# Altium schematic sheet 04 MCU.SchDoc("U_04 MCU")
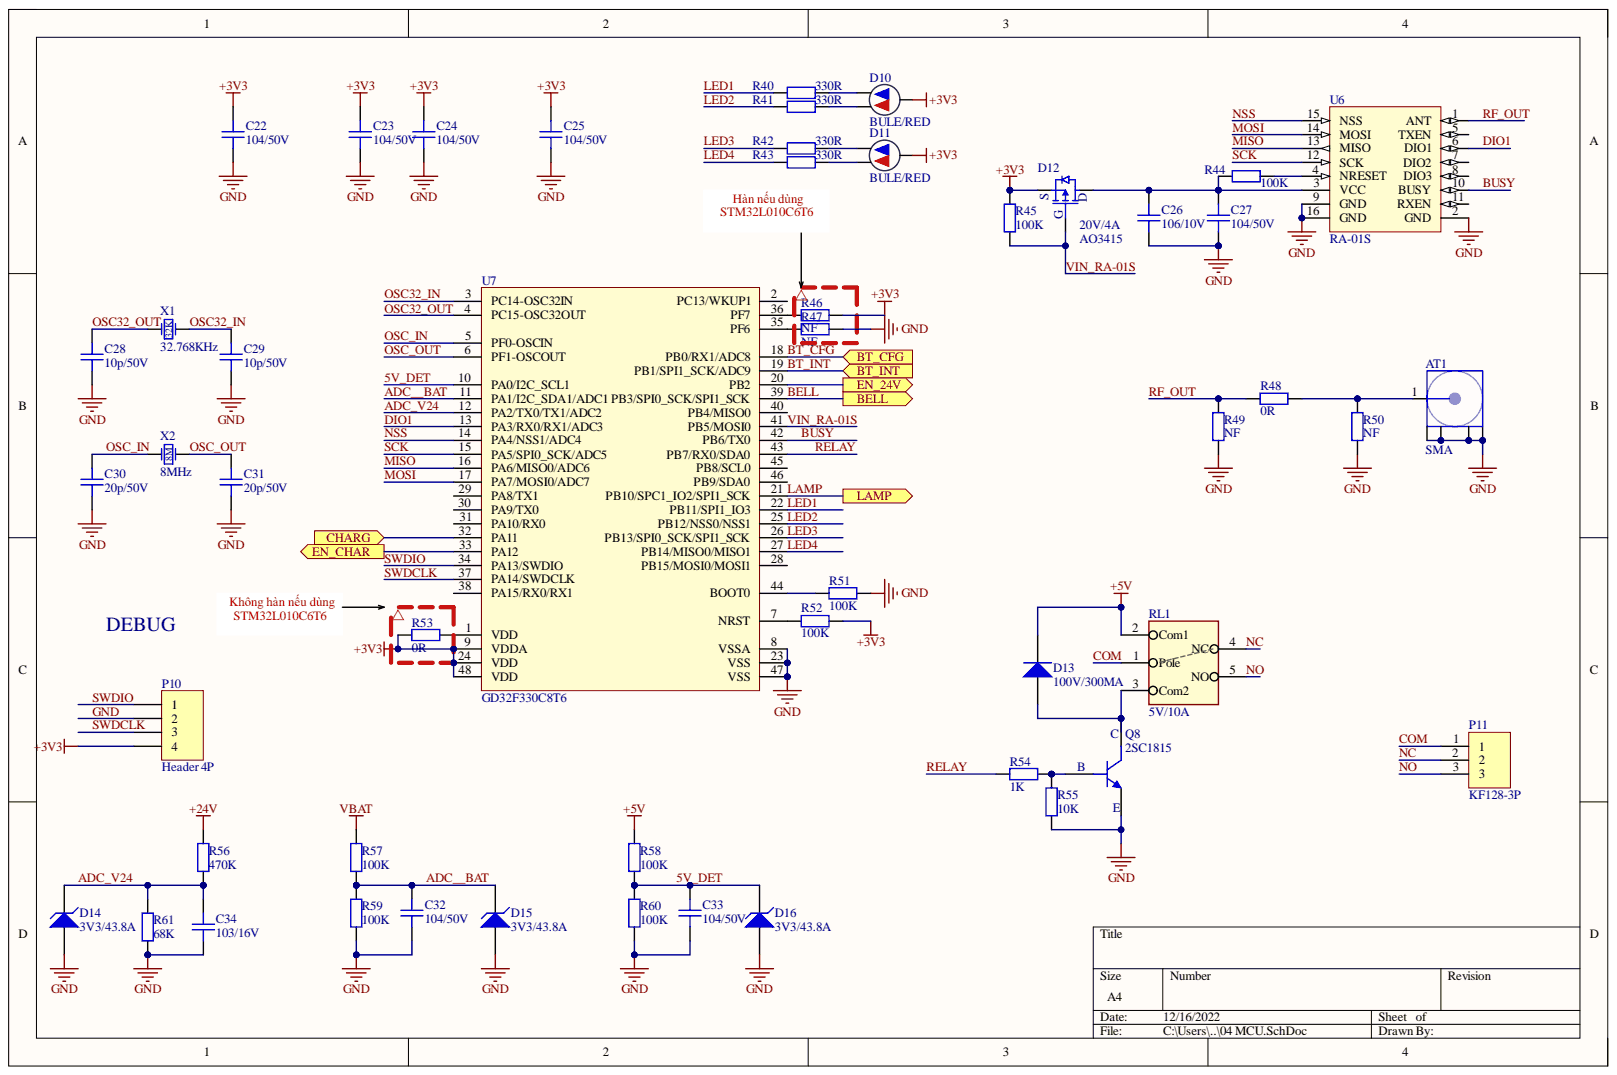
[diagram p0: 04 MCU.SchDoc]

## Parts
AT1  SMA  [SMA-KWE-17-V1]
C22  104/50V  [CAPC-0603 (1608) - HD]
C23  104/50V  [CAPC-0603 (1608) - HD]
C24  104/50V  [CAPC-0603 (1608) - HD]
C25  104/50V  [CAPC-0603 (1608) - HD]
C26  106/10V  [CAPC-0603 (1608) - HD]
C27  104/50V  [CAPC-0603 (1608) - HD]
C28  10p/50V  [CAPC-0603 (1608) - HD]
C29  10p/50V  [CAPC-0603 (1608) - HD]
C30  20p/50V  [CAPC-0603 (1608) - HD]
C31  20p/50V  [CAPC-0603 (1608) - HD]
C32  104/50V  [CAPC-0603 (1608) - HD]
C33  104/50V  [CAPC-0603 (1608) - HD]
C34  103/16V  [CAPC-0603 (1608) - HD]
D10  BULE/RED  [LED_3MM_DUALCOLOR]
D11  BULE/RED  [LED_3MM_DUALCOLOR]
D12  20V/4A  [SOT23_3]
D13  100V/300MA  [SOD-123]
D14  3V3/43.8A  [SOD123]
D15  3V3/43.8A  [SOD123]
D16  3V3/43.8A  [SOD123]
P10  Header 4P  [HDR1X4]
P11  KF128-3P  [KF128 - 3P 5.08MM]
Q8  2SC1815  [SOT23_3]
R40  330R  [RESC-0603 (1608) - HD]
R41  330R  [RESC-0603 (1608) - HD]
R42  330R  [RESC-0603 (1608) - HD]
R43  330R  [RESC-0603 (1608) - HD]
R44  100K  [RESC-0603 (1608) - HD]
R45  100K  [RESC-0603 (1608) - HD]
R46  NF  [RESC-0603 (1608) - HD]
R47  NF  [RESC-0603 (1608) - HD]
R48  0R  [RESC-0603 (1608) - HD]
R49  NF  [RESC-0603 (1608) - HD]
R50  NF  [RESC-0603 (1608) - HD]
R51  100K  [RESC-0603 (1608) - HD]
R52  100K  [RESC-0603 (1608) - HD]
R53  0R  [RESC-0603 (1608) - HD]
R54  1K  [RESC-0603 (1608) - HD]
R55  10K  [RESC-0603 (1608) - HD]
R56  470K  [RESC-0603 (1608) - HD]
R57  100K  [RESC-0603 (1608) - HD]
R58  100K  [RESC-0603 (1608) - HD]
R59  100K  [RESC-0603 (1608) - HD]
R60  100K  [RESC-0603 (1608) - HD]
R61  68K  [RESC-0603 (1608) - HD]
RL1  5V/10A  [RELAY 5V]
U6  RA-01S  [Lora1276 V1.2]
U7  GD32F330C8T6  [LQFP-48]
X1  32.768KHz  [CRYSTAL_ABS06 2x1.2mm]
X2  8MHz  [CRYSTAL_ABM3 5x3.2mm]

## Ports
BELL, BT_CFG, BT_INT, CHARG, EN_24V, EN_CHAR, LAMP

## Nets
+3V3: C22.2 C23.2 C24.2 C25.2 D10.2 D11.2 D12.2 P10.4 R45.2 R46.1 R52.2 R53.2 U7.9(VDDA) U7.24(VDD) U7.48(VDD)
+24V: R56.2
VBAT: R57.2
5V_DET: C33.2 D13.2 D16.2 R58.1 R58.2 R60.2 RL1.2(Com1) U7.10(PA0/I2C_SCL1)
ADC__BAT: C32.2 D15.2 R57.1 R59.2 U7.11(PA1/I2C_SDA1/ADC1)
ADC_V24: C34.2 D14.2 R56.1 R61.2 U7.12(PA2/TX0/TX1/ADC2)
BELL: U7.39(PB3/SPI0_SCK/SPI1_SCK) ; port BELL
BT_CFG: U7.18(PB0/RX1/ADC8) ; port BT_CFG
BT_INT: U7.19(PB1/SPI1_SCK/ADC9) ; port BT_INT
BUSY: U6.10(BUSY) U7.42(PB6/TX0)
CHARG: U7.32(PA11) ; port CHARG
COM: P11.1 RL1.1(Pole)
DIO1: U6.6(DIO1) U7.13(PA3/RX0/RX1/ADC3)
EN_24V: U7.20(PB2) ; port EN_24V
EN_CHAR: U7.33(PA12) ; port EN_CHAR
GND: AT1.2 C22.1 C23.1 C24.1 C25.1 C26.1 C27.1 C28.1 C29.1 C30.1 C31.1 C32.1 C33.1 C34.1 D14.1 D15.1 D16.1 P10.2 Q8.2 R47.1 R49.1 R50.1 R51.2 R55.1 R59.1 R60.1 R61.1 U6.2(GND) U6.9(GND) U6.16(GND) U7.8(VSSA) U7.23(VSS) U7.47(VSS)
LAMP: U7.21(PB10/SPC1_IO2/SPI1_SCK) ; port LAMP
LED1: R40.1 U7.22(PB11/SPI1_IO3)
LED2: R41.1 U7.25(PB12/NSS0/NSS1)
LED3: R42.1 U7.26(PB13/SPI0_SCK/SPI1_SCK)
LED4: R43.1 U7.27(PB14/MISO0/MISO1)
MISO: U6.13(MISO) U7.16(PA6/MISO0/ADC6)
MOSI: U6.14(MOSI) U7.17(PA7/MOSI0/ADC7)
NC: P11.2 RL1.4(NC)
NO: P11.3 RL1.5(NO)
NSS: U6.15(NSS) U7.14(PA4/NSS1/ADC4)
OSC32_IN: C29.2 U7.3(PC14-OSC32IN) X1.2
OSC32_OUT: C28.2 U7.4(PC15-OSC32OUT) X1.1
OSC_IN: C30.2 U7.5(PF0-OSCIN) X2.1
OSC_OUT: C31.2 U7.6(PF1-OSCOUT) X2.2
RELAY: R54.1 U7.43(PB7/RX0/SDA0)
RF_OUT: R48.1 R49.2 U6.1(ANT)
SCK: U6.12(SCK) U7.15(PA5/SPI0_SCK/ADC5)
SWDCLK: P10.3 U7.37(PA14/SWDCLK)
SWDIO: P10.1 U7.34(PA13/SWDIO)
VIN_RA-01S: D12.1 R45.1 U7.41(PB5/MOSI0)
NetAT1_1: AT1.1 R48.2 R50.2
NetC26_2: C26.2 C27.2 D12.3 R44.2 U6.3(VCC)
NetD10_1: D10.1 R41.2
NetD10_3: D10.3 R40.2
NetD11_1: D11.1 R43.2
NetD11_3: D11.3 R42.2
NetD13_1: D13.1 Q8.3 RL1.3(Com2)
NetQ8_1: Q8.1 R54.2 R55.2
NetR44_1: R44.1 U6.4(NRESET)
NetR46_2: R46.2 U7.36(PF7)
NetR47_2: R47.2 U7.35(PF6)
NetR51_1: R51.1 U7.44(BOOT0)
NetR52_1: R52.1 U7.7(NRST)
NetR53_1: R53.1 U7.1(VDD)
NetU6_5: U6.5(TXEN)
NetU6_7: U6.7(DIO2)
NetU6_8: U6.8(DIO3)
NetU6_11: U6.11(RXEN)
NetU7_2: U7.2(PC13/WKUP1)
NetU7_28: U7.28(PB15/MOSI0/MOSI1)
NetU7_29: U7.29(PA8/TX1)
NetU7_30: U7.30(PA9/TX0)
NetU7_31: U7.31(PA10/RX0)
NetU7_38: U7.38(PA15/RX0/RX1)
NetU7_40: U7.40(PB4/MISO0)
NetU7_45: U7.45(PB8/SCL0)
NetU7_46: U7.46(PB9/SDA0)

## Library data of the parts
AT1 = GKZS-SMAJZM-KWE-17.3: MFR: GAOKE; Company ID: VT01148; Solder: WAVE; Component Kind: Standard; MPN: GKZS-SMAJZM-KWE-17.3; Value: SMA; Library Name: 04.Header-Connecter.SchLib
C22-C25 C27 = C0603C104K5RACTU: CAP CER 0.1uF 50V 10% X7R 0603; Solder: SMT; Category: CAPACITOR; CMT: 104/50V; Component Kind: Standard; Library Name: 01.Passives.SchLib; MPN: C0603C104K5RACTU; PinCount: 2
C26 = CL10A106KP8NNNC: CAP CER 10uF 10% 10V X5R 0603; CompanyID: VT-00984; Solder: SMT; Category: CAPACITOR; Component Kind: Standard; Library Name: 01.Passives.SchLib; MPN: CL10A106KP8NNNC; PinCount: 2; Value: 106/10V
C28 C29 = CL10C100JB8NNND: CAP CER 10PF 50V NP0 0603; MFR: Samsung Electro-Mechanics; Solder: SMT; Component Kind: Standard; Library Name: 01.Passives.SchLib; MPN: CL10C100JB8NNND; Value: 10p/50V
C30 C31 = CL10C200JB8NNNC: CAP CER 20pF 5% 50V C0G/NP0 0603; Company ID: VT00080; Solder: SMT; Component Kind: Standard; Library Name: 01.Passives.SchLib; MPN: CL10C200JB8NNNC; Value: 20p/50V
C32 C33 = C0603C104K5RACTU: CAP CER 0.1uF 50V 10% X7R 0603; CompanyID: VT-00982; Solder: SMT; Category: CAPACITOR; Component Kind: Standard; Library Name: 01.Passives.SchLib; MPN: C0603C104K5RACTU; Value: 104/50V
C34 = CC0603KRX7R7BB103: CAP CER 10nF 16V 10% X7R 0603; CompanyID: VT-00592; Solder: SMT; Category: CAPACITOR; Component Kind: Standard; Library Name: 01.Passives.SchLib; MPN: CC0603KRX7R7BB103; Value: 103/16V
D10 D11 = LED BLUE/RED 3MM: LED DUAL COLOR BLUE/RED 3MM COMMON ANODE; MFR: CHINA; MPN: LED BLUE/RED 3MM ANODE; SOLDE: WAVE; CATEGORY: LED DIDOE; Component Kind: Standard; Library Name: 03.Semiconductor.SchLib; VALUE: BULE/RED
D12 = AO3415: MOSFET P-CH 20V 4A SOT23; MFR: Alpha & Omega Semiconductor Inc.; Company ID: VT00490; CATEGORY: DIODE; Component Kind: Standard; Library Name: 03.Semiconductor.SchLib; MPN: AO3415; SOLDER: SOT23; VALUE: 20V/4A
D13 = 1N4148-W-7: DIODE GEN PURP 100V 300MA SOD123; MFR: Diodes Incorporated; MPN: 1N4148W-7-F; CompanyID: VT00188; Solder: SMT; CMT: 100V/300MA; Component Kind: Standard; Library Name: 01.Passives.SchLib
D14-D16 = PTVS3V3S1UR,115: TVS DIODE 3.3VWM 8VC CFP3; MFR: Nexperia USA Inc.; Solder: SOD-123W; Category: DIODE; Component Kind: Standard; Library Name: 03.Semiconductor.SchLib; MPN: PTVS3V3S1UR,115; Value: 3V3/43.8A
P10 = Header 4x1: Header, 4 Pin Pitch 2.54mm; MPN: S1012EC-40-ND; CompanyID: VT-00125; Solder: WAVE; Component Kind: Standard; Value: Header 4P; Library Name: 04.Header-Connecter.SchLib
P11 = KF128-3P 5.08MM: MFR: CHINA; LKCL: CLA1935; SOLDER: HAND; CATEGORY: CONNECTOR; Component Kind: Standard; Description: KF128-3P 5.08MM; MPN: KF128-3P 5.08MM; Value: KF128-3P; Library Name: 04.Header-Connecter.SchLib
Q8 = 2SC1815: TRANS NPN 50V 0.15A SOT23-3; Solder: SMT; Component Kind: Standard; Library Name: 03.Semiconductor.SchLib; MPN: 2SC1815; Value: 2SC1815
R40-R43 = RC0603FR-07330RL: RES SMD 330 OHM 1% 1/10W 0603; MFR: Yageo; Company ID: VT00443; Solder: SMT; Category: Resistor; Component Kind: Standard; Library Name: 01.Passives.SchLib; MPN: RC0603FR-07330RL; Value: 330R
R44 R45 R51 R52 R57-R60 = RC0603FR-07100KL: RES SMD 100K OHM 1% 1/10W 0603; CompanyID: VT-00332; Solder: SMT; Category: RESISTOR; Component Kind: Standard; Library Name: 01.Passives.SchLib; MPN: RC0603FR-07100KL; Value: 100K
R46 R47 R49 R50 = RC0603FR-070RL: RES SMD 0.0OHM JUMPER 1/10W 0603; MFR: Yageo; Company ID: VT00423; Solder: SMT; Value: 0R; Category: Resistor; Component Kind: Standard; Library Name: 01.Passives.SchLib; MPN: RC0603FR-070RL
R48 R53 = RC0603FR-070RL: RES SMD 0.0OHM JUMPER 1/10W 0603; MFR: Yageo; Company ID: VT00423; Solder: SMT; Category: Resistor; Component Kind: Standard; Library Name: 01.Passives.SchLib; MPN: RC0603FR-070RL; Value: 0R
R54 = RC0603FR-071KL: RES SMD 1 KOHM 1% 1/10W 0603; CompanyID: VT00432; Solder: SMT; Category: RESISTOR; CMT: 1K; Component Kind: Standard; Library Name: 01.Passives.SchLib; MPN: RC0603FR-071KL
R55 = RC0603FR-0710KL: RES 10K OHM 1% 1/10W 0603; MFR: YAGEO; Solder: SMT; Component Kind: Standard; Library Name: 01.Passives.SchLib; MPN: RC0603FR-0710KL; Value: 10K
R56 = RC0603FR-07470KL: RES SMD 470K OHM 1% 1/10W 0603; Solder: SMT; Category: RESISTOR; Component Kind: Standard; Library Name: 01.Passives.SchLib; MPN: RC0603FR-07470K; Value: 470K
R61 = CRCW060368K0FKEA: RES SMD 68K OHM 1% 1/10W 0603; MFR: Vishay Dale; Solder: SMT; Category: Resistor; Component Kind: Standard; Library Name: 01.Passives.SchLib; MPN: CRCW060368K0FKEA; Value: 68K
RL1 = Relay 5V: SRD-05VDC-SL-C Relay 5V DC 10A 1 FORM C; Manufacturer: Songle; Solder: Wave; Category: Relay; Component Kind: Standard; Library Name: 02.Power,Protection.SchLib; MPN: SRD-05VDC-SL-C; Value: 5V/10A
U6 = RA-01S: Module lora RA-01S SX1268; MFR: AI-THINKER; Solder: SMT 16; Category: Module; Component Kind: Standard; Library Name: 05.IC.SchLib; MPN: RA-01S; Value: RA-01S
U7 = GD32F330C8T6: ARM® Cortex®-M4 32-bit MCU 64K Flash 8K RAM; MFR: Gigadevice; Category: IC; Component Kind: Standard; Library Name: 05.IC.SchLib; MPN: GD32F330C8T6; Solder: LQFP-48; Value: GD32F330C8T6
X1 = ABS06-107-32.768kHz-T: CRYSTAL 32.7680KHZ 4pF SMD; CompanyID: VT00159; Solder: SMT; Category: CRYSTAL; CMT: 32.768KHz; Component Kind: Standard; Library Name: 01.Passives.SchLib; MPN: ABS06-107-32.768kHz-T
X2 = ABM3-8.000MHZ-D2Y-T: CRYSTAL 8.0000MHZ 18pF SMD; Company ID: VT00157; Solder: SMT; Component Kind: Standard; Library Name: 01.Passives.SchLib; MPN: ABM3-8.000MHZ-D2Y-T; Value: 8MHz
